# Altium schematic sheet payloadSheet3.SchDoc(payloadSheet3)
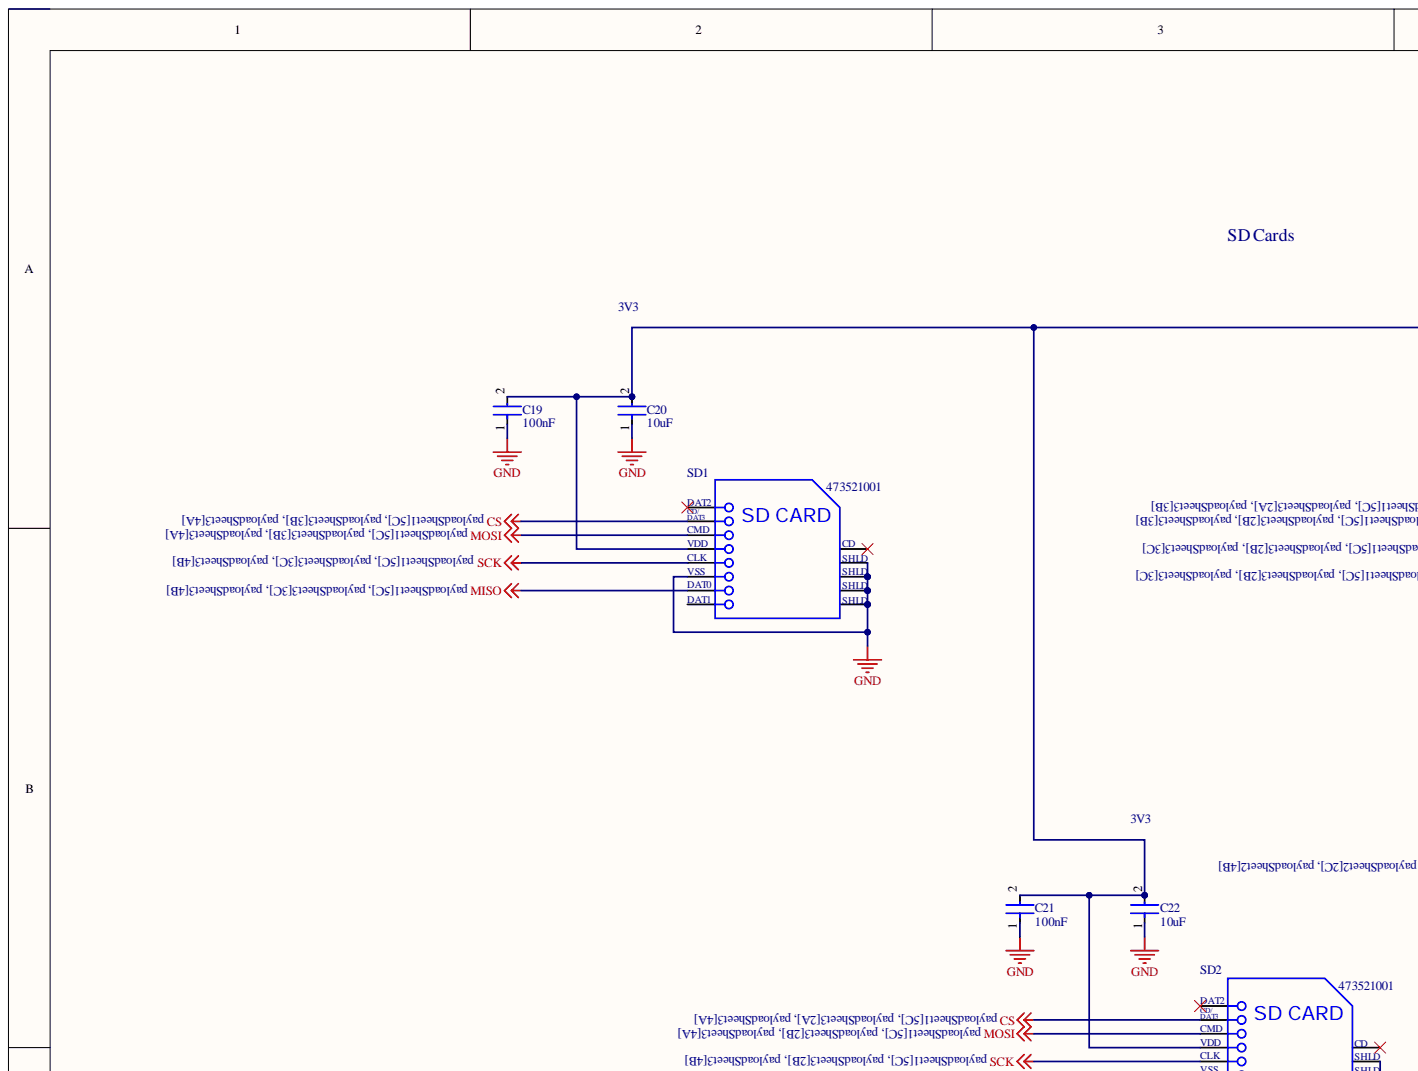
[diagram p0: payloadSheet3.SchDoc, top left]
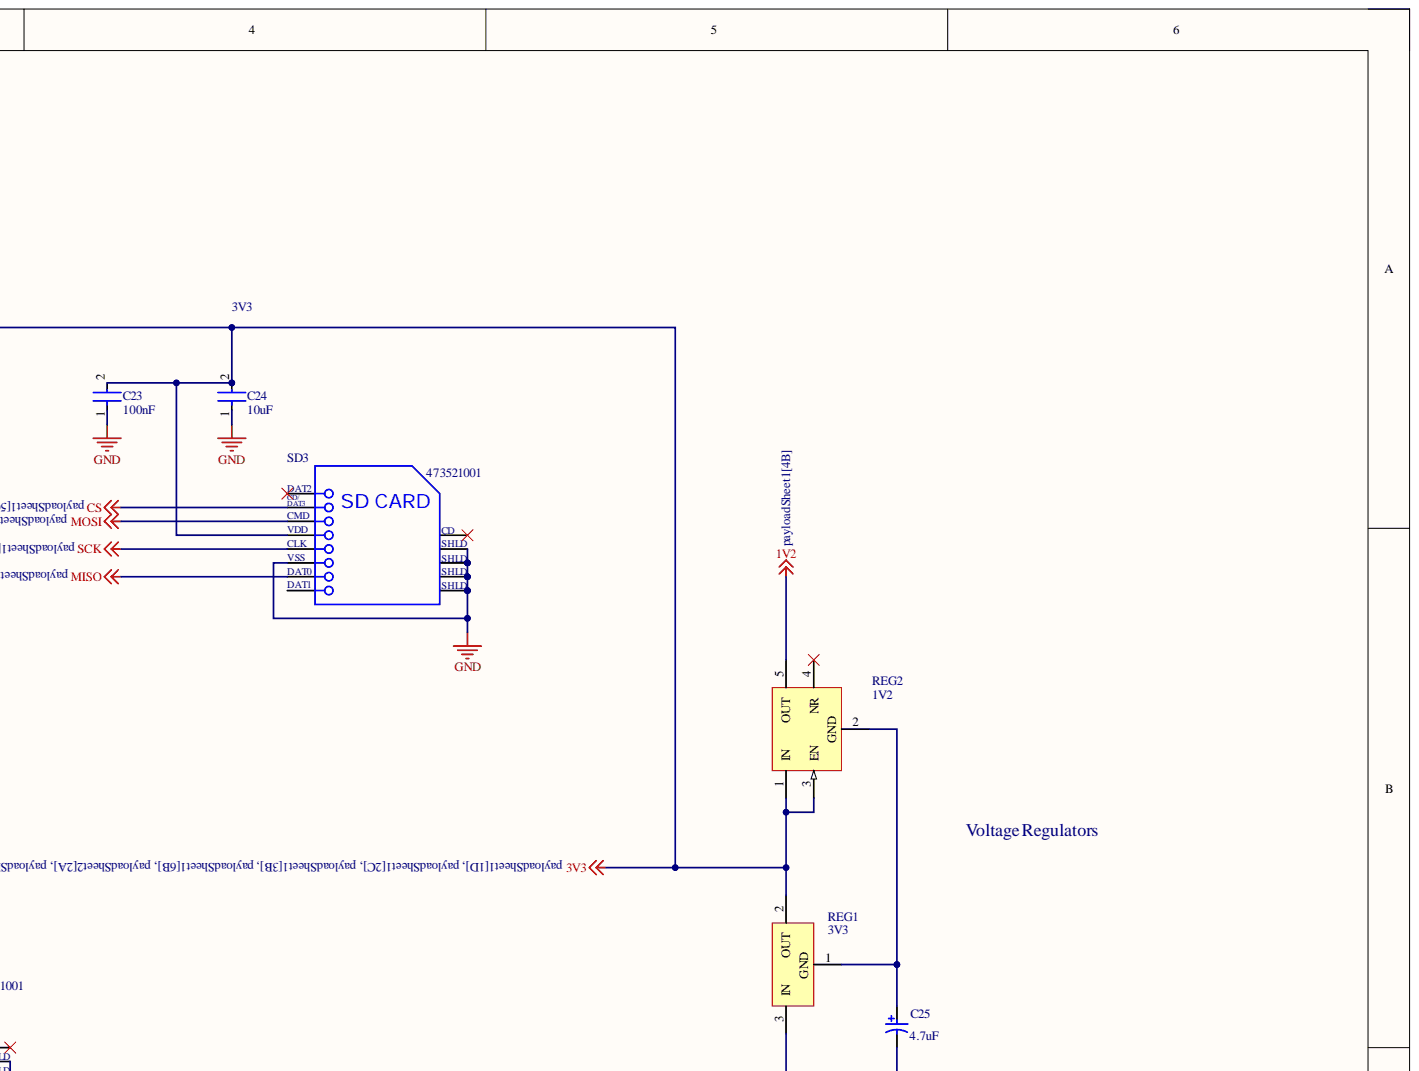
[diagram p1: payloadSheet3.SchDoc, top right]
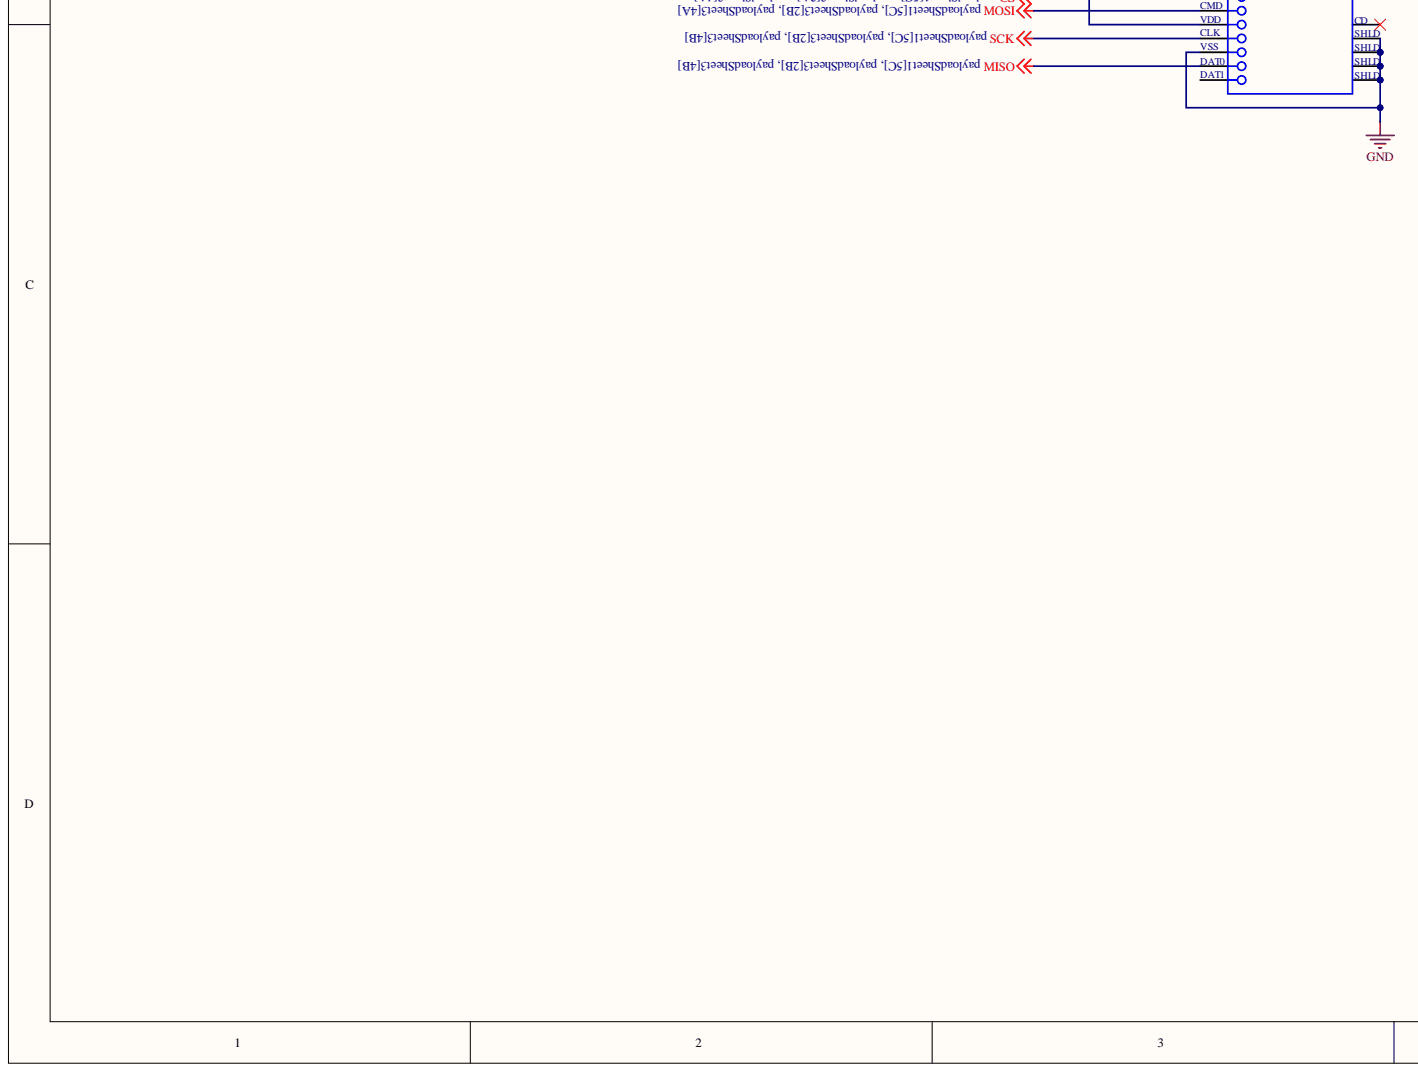
[diagram p2: payloadSheet3.SchDoc, bottom left]
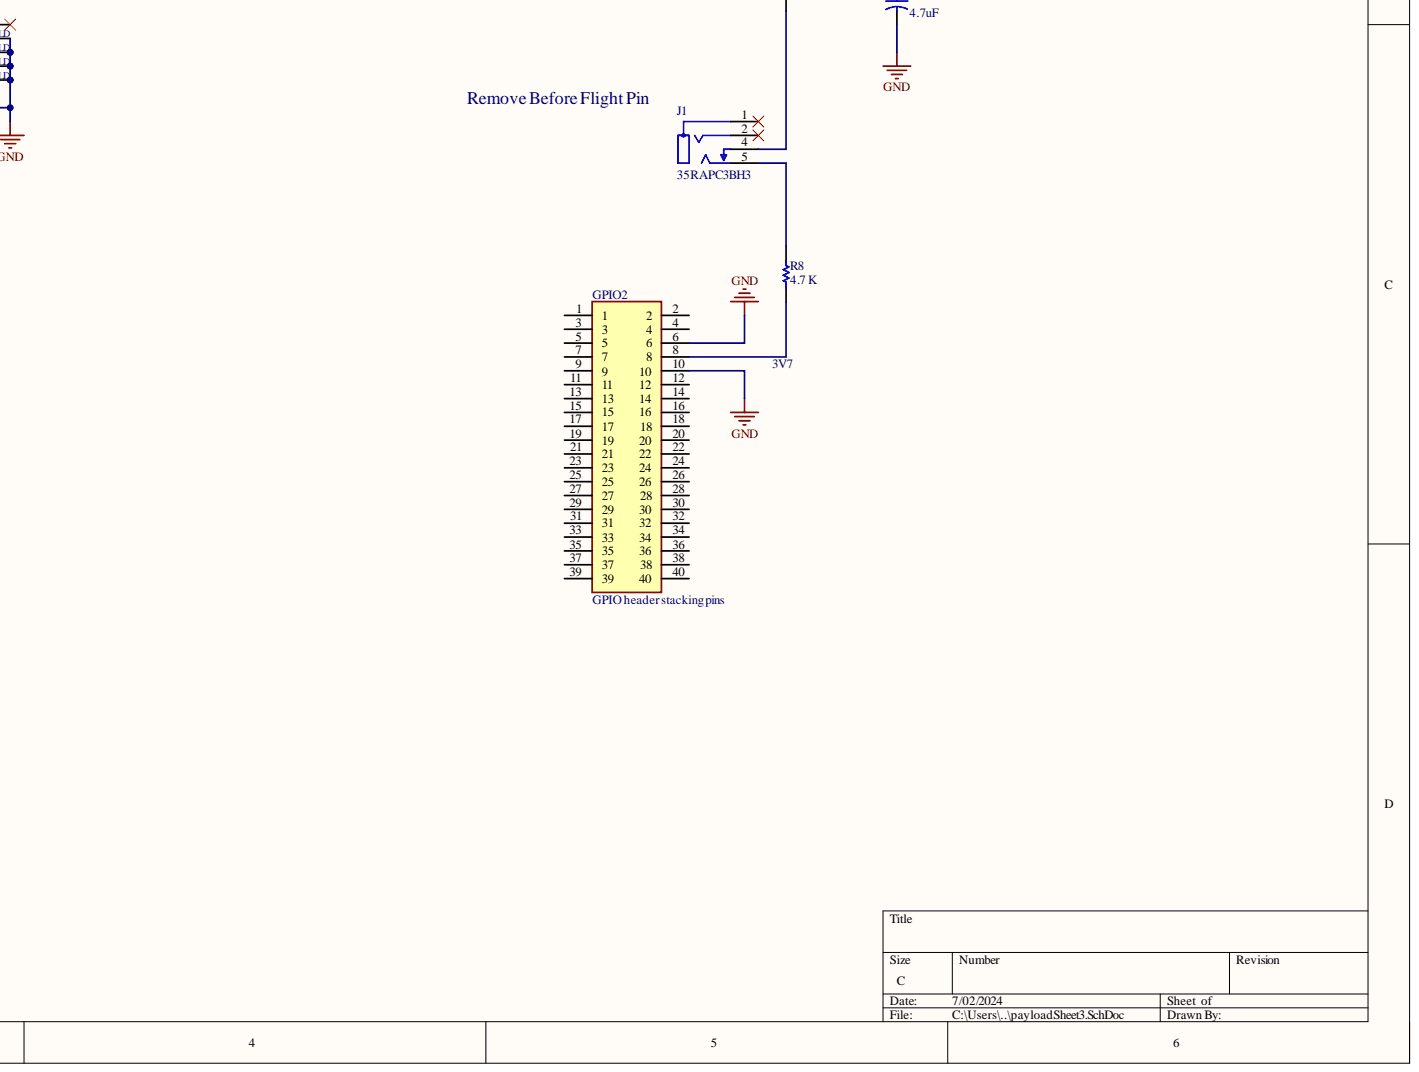
[diagram p3: payloadSheet3.SchDoc, bottom right]

## Parts
C19  100nF  [FP-C322C104K5R5TA-MFG]
C20  10uF  [FP-RCE3DB-MFG]
C21  100nF  [FP-C322C104K5R5TA-MFG]
C22  10uF  [FP-RCE3DB-MFG]
C23  100nF  [FP-C322C104K5R5TA-MFG]
C24  10uF  [FP-RCE3DB-MFG]
C25  4.7uF  [AVX-TAP475K016SCS]
GPIO2  GPIO header stacking pins  [GPIOheader]
J1  35RAPC3BH3  [FP-35RAPC3BH3-MFG]
R8  4.7 K  [RESC3116X65X40LL10T20]
REG1  3V3  [ADI-KC-3_L]
REG2  1V2  [DCK0005A_M]
SD1  473521001  [MOLX-473521001_V]
SD2  473521001  [MOLX-473521001_V]
SD3  473521001  [MOLX-473521001_V]

## Nets
1V2: REG2.5(OUT)
3V3: C19.2 C20.2 C21.2 C22.2 C23.2 C24.2 REG1.2 REG2.1(IN) REG2.3(EN) SD1.4 SD2.4 SD3.4
CS: SD1.2(SD_CARD) SD2.2 SD3.2(SD_CARD)
GND: C19.1 C20.1 C21.1 C22.1 C23.1 C24.1 C25.2 GPIO2.6 GPIO2.10 SD1.6 SD1.10 SD1.11 SD1.12 SD1.13 SD2.6 SD2.10 SD2.11 SD2.12 SD2.13 SD3.6 SD3.10 SD3.11 SD3.12 SD3.13
MISO: SD1.7 SD2.7 SD3.7
MOSI: SD1.3 SD2.3 SD3.3
SCK: SD1.5 SD2.5 SD3.5
NetC25_1: C25.1 REG1.1 REG2.2
NetGPIO2_8: GPIO2.8 R8.1
NetJ1_2: J1.2
NetJ1_4: J1.4 REG1.3
NetJ1_5: J1.5 R8.2

Not connected: GPIO2 1 2 3 4 5 7 9 11 12 13 14 15 16 17 18 19 20 21 22 23 24 25 26 27 28 29 30 31 32 33 34 35 36 37 38 39 40; J1 1; REG2 4(NR); SD1 1 8 9; SD2 1(SD_CARD) 8 9; SD3 1 8 9

## Library data of the parts
C19 C21 C23 = CMP-03020-034661-1: CAP CER 0.1UF 50V X7R RADIAL; Dielectric Material: Ceramic; Dissipation Factor: 2.5%; Lead Diameter: 0.51mm; Lead Length: 7mm; Lead/Base Style: Formed Leads; Tolerance: 10%; Capacitance: 100nF; Case/Package: Radial; Component Kind: Standard; Construction: RADIAL; Depth: 3.18mm; Dielectric: X7R; Height: 3.18mm; Height - Seated (Max): 0.26inch; Lead Free: Lead Free; Lead Pitch: 5.08mm; Length: 5.08mm; Library Name: Altium Content Vault; Material: Ceramic; Max Operating Temperature: 125°C; Min Operating Temperature: -55°C; Mount: Through Hole; Number of Pins: 2; Package Quantity: 500; Packaging: Bulk; Radiation Hardening: No; REACH SVHC: No SVHC; RoHS Compliant: Yes; Series: Golden Max™; Termination: Radial; Voltage: 50V; Voltage Rating: 50V; Voltage Rating (DC): 50V; Weight: 0.0625lb; Width: 0.125inch
C20 C22 C24 = CMP-06035-033894-1: Cap Ceramic 10uF 25V X7R ±10% Radial 2.5mm +125°C Automotive Bulk; Lead Diameter: 0.5mm; Lead/Base Style: Straight; Capacitance: 10uF; Case/Package: Radial; Component Kind: Standard; Dielectric: X7R; Height: 5mm; Height - Seated (Max): 0.197inch; Lead Pitch: 0.098inch; Length: 0.217inch; Library Name: Altium Content Vault; Max Operating Temperature: 125°C; Min Operating Temperature: -55°C; Mount: Through Hole; Package Quantity: 500; Packaging: Bulk; Ratings: AEC-Q200; Resistor Type: Ceramic; Termination: Radial; Tolerance: 10%; Voltage Rating: 25V; Voltage Rating (DC): 25V; Width: 0.157inch
C25 = CMP-2000-07562-1: Dipped Radial Capacitor, 4.7 uF, +/- 10%, 16 V, -55 to 125 degC, RoHS, Bulk; Manufacturer: AVX; Dielectric Material: Tantalum; Dissipation Factor: 6%; ESR (Equivalent Series Resistance): 5Ohm; Lead Diameter: 0.5mm; Leakage Current: 600nA; Life (Hours): 1000Hour; Polarity: Polar; Voltage: 16V; Capacitance: 4.7uF; Case\/Package: Dipped; Component Kind: Standard; Datasheet URL: http://datasheets.avx.com/tap.pdf; Datasheet Version: 08/2015; Diameter: 4.5mm; Height: 7.5mm; Height - Seated (Max): 0.295inch; Lead Free: LeadFree; Lead Pitch: 2.5mm; Length: 7.5mm; Library Name: Altium Content Vault; Manufacturer URL: http://www.avx.com/; Max Operating Temperature: 125degC; Min Operating Temperature: -55degC; Mount: PCB; Mounting Technology: ThroughHole; Number of Pins: 2; Package Description: 2-Pin Through Hole Device, Dia 4.5 mm, Height 7.5 mm; Package Quantity: 1000; Package Reference: TAP475K016SCS; Packaging: Bulk; Radiation Hardening: No; REACH SVHC: NoSVHC; RoHSCompliant: True; Termination: Radial; Tolerance: 10%; Voltage Rating: 16V; Voltage Rating (DC): 16V
GPIO2 = CMP-00004-1: Gpio Stacking Header for Pi A+/B+/Pi 2/Pi 3 - Extra-Long 2X20 Pins; Number of Positions: 40; Parameter 1: System; Pitch: 0.1inch; System: Connector; Color: Black; Component Kind: Standard; Connector Type: Header; Contact Plating: Gold; Contact Type: Female Socket; Mounting Technology: Through Hole; Number of Rows: 2; Packaging: Bulk; Row Spacing: 0.1inch; Series: -; Termination: Solder; Library Name: COSMIAC DJG
J1 = CMP-47983-000003-1: CONN JACK STEREO 3.5MM R/A; Body Color: Black; Body Material: Brass; Gender: Female; Number of Ports: 1; Number of Positions: 3; Shielding: Unshielded; Voltage Rating: 500V; Color: Black; Component Kind: Standard; Contact Material: Copper Alloy; Contact Plating: Silver; Housing Material: PBT; Lead Free: Lead Free; Library Name: Altium Content Vault; Mount: Through Hole; Number of Terminals/Pins: 3; Orientation: Right Angle; Package Quantity: 1; Packaging: Bulk; RoHS Compliant: Yes; Series: 35; Termination: Solder
R8 = CMP-2000-06971-1: Chip Resistor, 4.7 Ohm, +/- 1%, 250 mW, -55 to 155 degC, 1206 (3216 Metric), RoHS, Tape and Reel; Brand: Yageo; Power Rating: 250mW(1/4W); Resistance: 4.7Ohms; Temperature Coefficient: 200PPM/C; Unit Weight: 0.000571oz; Voltage Rating: 200V; Case Code - in: 1206; Case Code - mm: 3216; Component Kind: Standard; Datasheet URL: http://www.yageo.com/documents/recent/PYu-RC_Group_51_RoHS_L_7.pdf; Datasheet Version: Rev. 7, 05/2017; Height: 0.55mm; Length: 3.2mm; Library Name: Altium Content Vault; Manufacturer: Yageo; Manufacturer URL: http://www.yageo.com/; Mounting Technology: SurfaceMount; Operating Temperature Range: -55Cto+155C; Package / Case: 1206(3216metric); Package Description: 2-Pin Surface Mount Device, Body 3.1 x 1.6 mm; Package Reference: 1206; Packaging: Reel; Product: ThickFilmResistorsGeneralPurpose; Product Category: ThickFilmResistors; RoHS: True; Series: RC1206; Termination Style: SMD/SMT; Tolerance: 1%; Type: GeneralPurposeChipResistors; Width: 1.6mm
REG1 = CMP-0786-00152-1: High Accuracy, Ultralow IQ, 1 A, anyCAP(R) Low Dropout Regulator, 2.7 to 8 V Vin, 3.3 V Vout, Industrial, 3-pin SOT223 (KC-3), Reel; Manufacturer: Analog Devices; PartNumber: ADP3338AKCZ-3.3-RL; Iout max: 1A; Preset Vout Values (V): 3.3; Supply Current IGND @ Small Load: 110uA; Vin (max) (V): 8; Vin (min) (V): 2.7; Code_JEDEC: TO-261-AA; Component Kind: Standard; ComponentLink1Description: Manufacturer URL; ComponentLink1URL: http://www.analog.com/en/index.html; ComponentLink2Description: Datasheet; ComponentLink2URL: http://www.analog.com/static/imported-files/data_sheets/ADP3338.pdf; ComponentLink3Description: Package Specification; ComponentLink3URL: http:/www.analog.com/static/imported-files/packages/PKG_PDF/SOT_223(KC)/KC_3.pdf; ComponentLink4Description: URL Link1; ComponentLink4URL: Product URL:http://www.analog.com/en/ADP3338/productSearch.html; DatasheetVersion: Rev. B; Library Name: Altium Content Vault; Mounting Technology: Surface Mount; PackageDescription: 3-Pin Small Outline Transistor Package, Body 3.5 x 6.5 mm, Pitch 2.3 mm; PackageReference: KC-3; PackageVersion: 11-20-2012-B, 11/2012; Packing: Reel
REG2 = CMP-0394-00845-2: Single Output High PSRR LDO, 150 mA, Fixed 1.2 V Output, 2.5 to 6.5 V Input, 5-pin SC70 (DCK), -40 to 125 degC, Green (RoHS & no Sb/Br); Manufacturer: Texas Instruments; PartNumber: TPS71712DCKT; Accuracy(%): 3; Fixed Output Options(V): 1.2; Iout(Max)(A): 0.15; Iq(Typ)(mA): 0.05; SubFamily: Single Channel LDO; Vdo(Typ)(mV): 170; Vin(Max)(V): 6.5; Vin(Min)(V): 2.5; Code_JEDEC: MO-203; Component Kind: Standard; ComponentLink1Description: Manufacturer URL; ComponentLink1URL: http://www.ti.com/; ComponentLink2Description: Datasheet; ComponentLink2URL: http://www.ti.com/general/docs/lit/getliterature.tsp?genericPartNumber=TPS71712&fileType=pdf; ComponentLink3Description: Package Specification; ComponentLink3URL: http://www.ti.com/litv/pdf/mpds025f; DatasheetVersion: SBVS068G; Eco-Plan: Green (RoHS & no Sb/Br); Library Name: Altium Content Vault; Mounting Technology: Surface Mount; PackageDescription: 5-Pin Plastic Small-Outline, Body 2 x 1.25 mm, Pitch 0.65 mm; PackageReference: DCK0005A; PackageVersion: 4093553-3/G; Vout Adj(Max)(V): 1.2; Vout Adj(Min)(V): 1.2
SD1-SD3 = CMP-2000-05848-1: MicroSD(TM), Pitch 1.1 mm, 8 Position, Height 1.88 mm, -20 to 85 degC, RoHS, Tape and Reel; Manufacturer: Molex; Component Kind: Standard; Datasheet URL: http://www.molex.com/pdm_docs/sd/473521001_sd.pdf; Datasheet Version: Rev. G, 01/2012; Library Name: Altium Content Vault; Manufacturer URL: http://www.molex.com/; Mounting Technology: SurfaceMount; Package Description: MicroSD(TM), Pitch 1.1 mm, 8 Position, Height 1.88 mm; Package Reference: 473521001
